# Altium schematic sheet PowerUnit.SchDoc(PowerUnit)
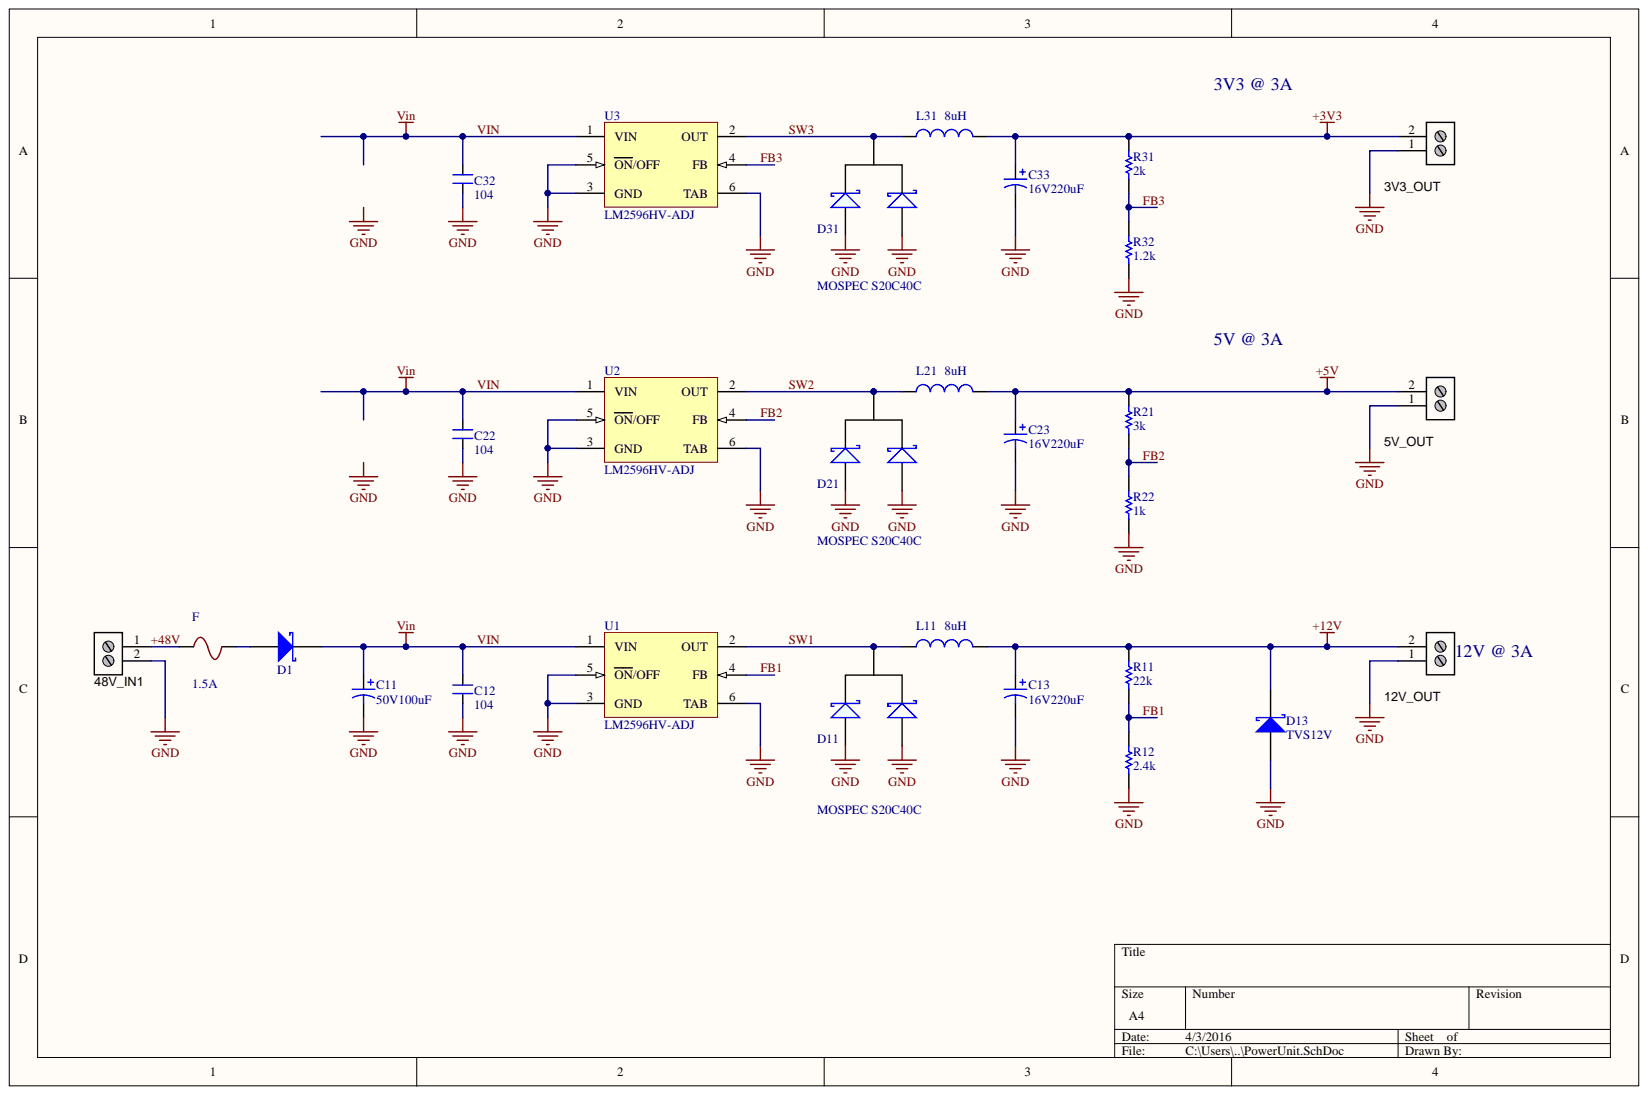
[diagram p0: PowerUnit.SchDoc]

## Parts
3V3_OUT  Stackable ScrewTerm  [2P_PCB_ScrewTerm_200mil]  (value not drawn)
5V_OUT  Stackable ScrewTerm  [2P_PCB_ScrewTerm_200mil]  (value not drawn)
12V_OUT  Stackable ScrewTerm  [2P_PCB_ScrewTerm_200mil]  (value not drawn)
48V_IN1  Stackable ScrewTerm  [2P_PCB_ScrewTerm_200mil]  (value not drawn)
C11  value 50V100uF  [CAP 3.8mm]  (hidden comment: Cap)
C12  value 104  [CAPC1608N]  (hidden comment: CAP NP)
C13  value 16V220uF  [CAP 3.8mm]  (hidden comment: Cap)
C22  value 104  [CAPC1608N]  (hidden comment: CAP NP)
C23  value 16V220uF  [CAP 3.8mm]  (hidden comment: Cap)
C32  value 104  [CAPC1608N]  (hidden comment: CAP NP)
C33  value 16V220uF  [CAP 3.8mm]  (hidden comment: Cap)
D1  SS14  [Diode 3A]  (value not drawn)
D11  MOSPEC S20C40C  [TO-220]
D13  TVS12V  [DO-214AA]
D21  MOSPEC S20C40C  [TO-220]
D31  MOSPEC S20C40C  [TO-220]
F  1.5A  [FUSE_SR-5-BK]
L11  value 8uH  [Indutor V]  (hidden comment: Inductor)
L21  value 8uH  [Indutor V]  (hidden comment: Inductor)
L31  value 8uH  [Indutor V]  (hidden comment: Inductor)
R11  value 22k  [RESC2012N]  (hidden comment: Res)
R12  value 2.4k  [RESC2012N]  (hidden comment: Res)
R21  value 3k  [RESC2012N]  (hidden comment: Res)
R22  value 1k  [RESC2012N]  (hidden comment: Res)
R31  value 2k  [RESC2012N]  (hidden comment: Res)
R32  value 1.2k  [RESC2012N]  (hidden comment: Res)
U1  LM2596HV-ADJ  [TS5B_N]
U2  LM2596HV-ADJ  [TS5B_N]
U3  LM2596HV-ADJ  [TS5B_N]

## Nets
+3V3: 3V3_OUT.2 C33.1 L31.2 R31.2
+5V: 5V_OUT.2 C23.1 L21.2 R21.2
+12V: 12V_OUT.2 C13.1 D13.2 L11.2 R11.2
GND: 3V3_OUT.1 5V_OUT.1 12V_OUT.1 48V_IN1.2 C11.2 C12.1 C13.2 C22.1 C23.2 C32.1 C33.2 D11.1 D11.3 D13.1 D21.1 D21.3 D31.1 D31.3 R12.1 R22.1 R32.1 U1.3(GND) U1.5(ON/OFF) U1.6(TAB) U2.3(GND) U2.5(ON/OFF) U2.6(TAB) U3.3(GND) U3.5(ON/OFF) U3.6(TAB)
+48V: 48V_IN1.1 F.2
FB1: R11.1 R12.2 U1.4(FB)
FB2: R21.1 R22.2 U2.4(FB)
FB3: R31.1 R32.2 U3.4(FB)
SW1: D11.2 L11.1 U1.2(OUT)
SW2: D21.2 L21.1 U2.2(OUT)
SW3: D31.2 L31.1 U3.2(OUT)
VIN: C11.1 C12.2 C22.2 C32.2 D1.2 U1.1(VIN) U2.1(VIN) U3.1(VIN)
NetD1_1: D1.1 F.1

## Library data of the parts
3V3_OUT 5V_OUT 12V_OUT 48V_IN1 = 2P_ScrewTerm_90S_3.81MM: Terminal Block Screw Type THT 2-Position 3.81mm pitch; Manufacturer 1: Tyco Electronics; Manufacturer Part Number 1: 1776113-2; Pitch: 0.150" (3.81mm); Positions Per Level: 2; Value: 2 position; Category: Connectors Interconnects; Color: Black; Component Kind: Standard; Library Name: PBL - Connectors.SCHLIB; Package / Case: Side Entry; 3.81mm pitch; Supplier 1: Digi-Key; Supplier Part Number 1: A97976-ND
D1 D13 = Diode Schottky: Component Kind: Standard; Library Name: Tuan.SchLib
D11 D21 D31 = Diode x2: Component Kind: Standard; Library Name: Tuan.SchLib
F = Fuse: Component Kind: Standard; Library Name: Tuan.SchLib
U1-U3 = LM2596S-ADJ: SIMPLE SWITCHER Power Converter 150 KHz 3A Step-Down Voltage Regulator; Manufacturer: National Semiconductor; PackageTopMarking: NSUZXYTT*LM2596S*-ADJ P+; PowerWise: N; Code_JEDEC: MO-169-AB; Component Kind: Standard; ComponentLink1Description: LM2596 Datasheet; ComponentLink1URL: http://www.national.com/ds/LM/LM2596.pdf; ComponentLink2Description: LM2596 Web Page; ComponentLink2URL: http://www.national.com/pf/LM/LM2596.html; ComponentLink3Description: TS5B Package Page; ComponentLink3URL: http://www.national.com/packaging/folders/ts5b.html; CompType: Switcher; DatasheetVersion: May-2002; DigiKeyPartNumber: LM2596S-ADJ-ND; FirstPublished: 4-Dec-2008; Library Name: NSC Switcher.IntLib; PackageDescription: TO-263, Molded, .400 x .390 x .180in, 5 Lead, .067in Pitch, Surface Mount; PackageReference: TS5B; PackageVersion: revD, Apr-2005; PartNumber: LM2596S-ADJ; PinCount: 5; RoHS: O; Publisher: Altium Limited
C11 C13 C23 C33 = Cap: Component Kind: Standard; Library Name: Tuan.SchLib
C12 C22 C32 = CAP NP: Component Kind: Standard; Library Name: Tuan.SchLib
L11 L21 L31 = Inductor: Component Kind: Standard; Library Name: Tuan.SchLib
R11 R12 R21 R22 R31 R32 = Res: Component Kind: Standard; Library Name: Tuan.SchLib
